# Altium schematic sheet Motor_driver.SchDoc
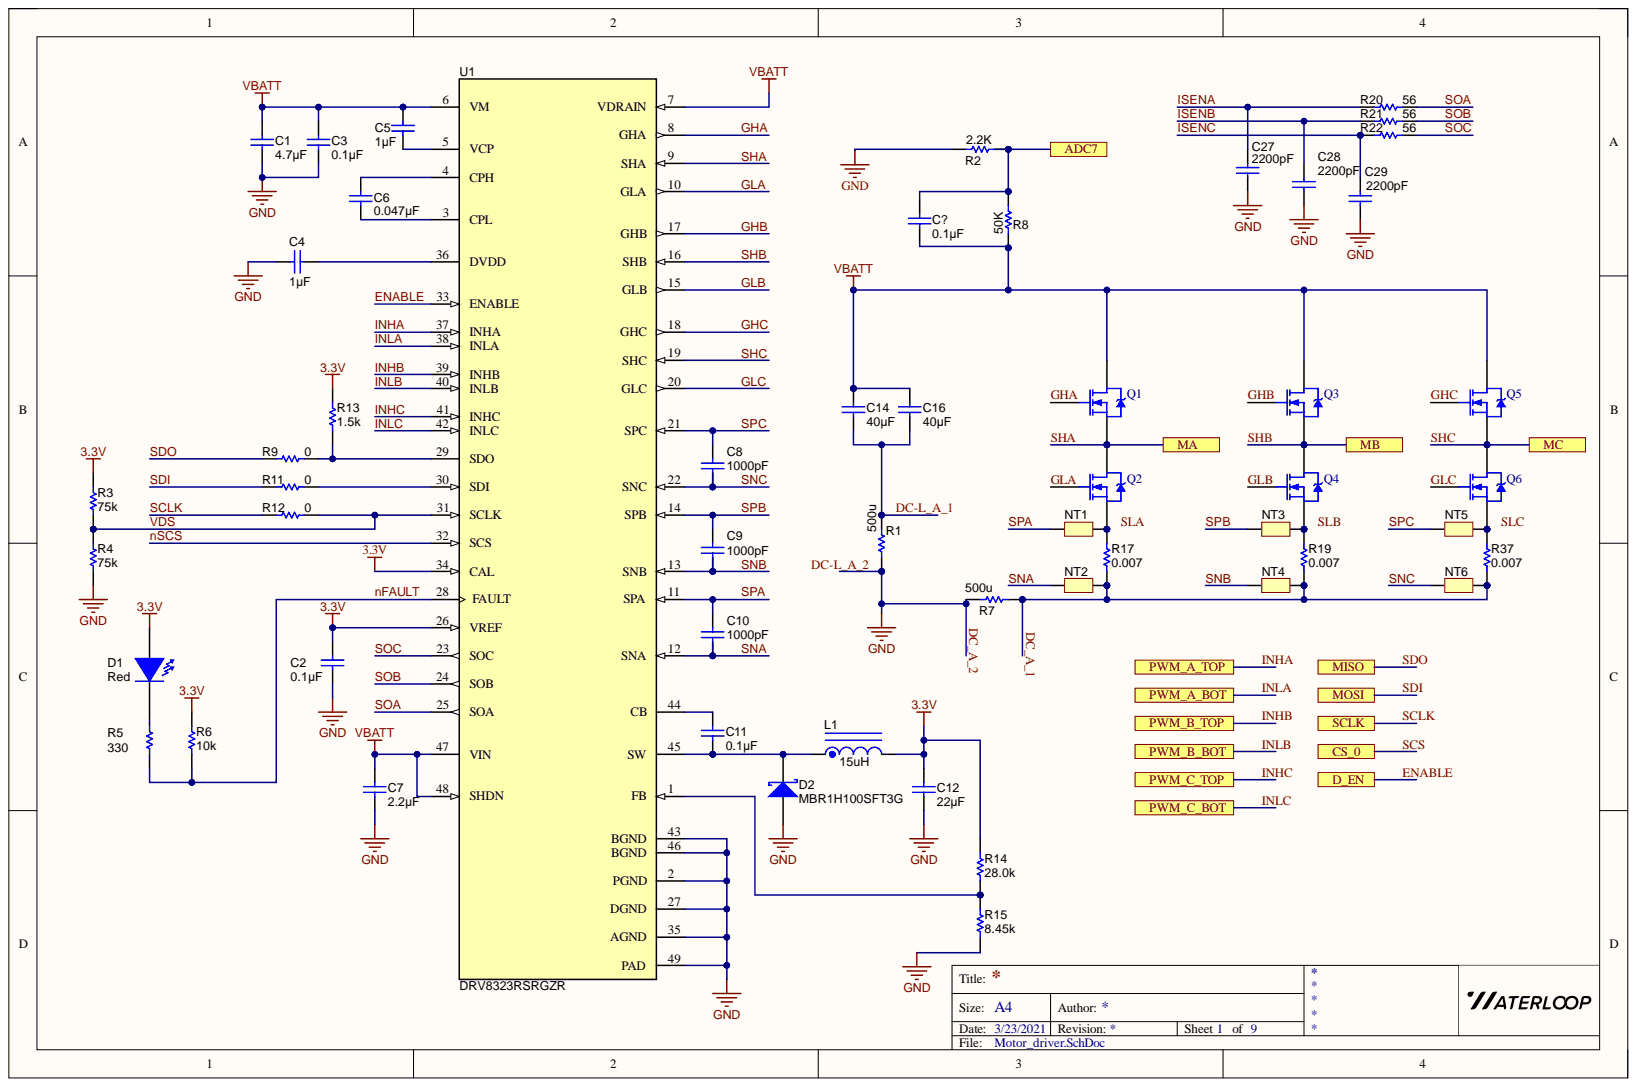
[diagram p0: Motor_driver.SchDoc]

## Parts
C1  ValueDisplayed: 4.7µF  [1210_270L]  (hidden comment: GRM32ER71K475KE14L)
C2  ValueDisplayed: 0.1µF  [0603L]  (hidden comment: GRM188R70J104KA01D)
C3  ValueDisplayed: 0.1µF  [0603L]  (hidden comment: GRM188R72A104KA35D)
C4  ValueDisplayed: 1µF  [0603L]  (hidden comment: GRM188R70J105KA01D)
C5  ValueDisplayed: 1µF  [0603L]  (hidden comment: 885012206052)
C6  ValueDisplayed: 0.047µF  [0603L]  (hidden comment: C1608X7S2A473K080AB)
C7  ValueDisplayed: 2.2µF  [1210L]  (hidden comment: HMK325B7225KN-T)
C8  value 1000pF  [0603L]  (hidden comment: GRM188R71C102KA01D)
C9  value 1000pF  [0603L]  (hidden comment: GRM188R71C102KA01D)
C10  value 1000pF  [0603L]  (hidden comment: GRM188R71C102KA01D)
C11  ValueDisplayed: 0.1µF  [0603L]  (hidden comment: 0603YC104JAT2A)
C12  ValueDisplayed: 22µF  [1206_180L]  (hidden comment: C3216X7S1A226M160AC)
C14  ValueDisplayed: 40µF  [0603L]  (hidden comment: 0603YC104JAT2A)
C16  ValueDisplayed: 40µF  [0603L]  (hidden comment: 0603YC104JAT2A)
C27  value 2200pF  [0603L]  (hidden comment: GRM188R71C222KA01D)
C28  value 2200pF  [0603L]  (hidden comment: GRM188R71C222KA01D)
C29  value 2200pF  [0603L]  (hidden comment: GRM188R71C222KA01D)
C?  ValueDisplayed: 0.1µF  [0603L]  (hidden comment: 0603YC104JAT2A)
D1  value Red  [LED_LTST-C170]  (hidden comment: LTST-C170KRKT)
D2  MBR1H100SFT3G  [SOD-123FL]
L1  value 15uH  [SD53]  (hidden comment: SD53-150-R)
NT1  Net-Tie  [Net-Tie_10mil]  (value not drawn)
NT2  Net-Tie  [Net-Tie_10mil]  (value not drawn)
NT3  Net-Tie  [Net-Tie_10mil]  (value not drawn)
NT4  Net-Tie  [Net-Tie_10mil]  (value not drawn)
NT5  Net-Tie  [Net-Tie_10mil]  (value not drawn)
NT6  Net-Tie  [Net-Tie_10mil]  (value not drawn)
Q1  NMOS-2  [TO-220AB]  (value not drawn)
Q2  NMOS-2  [TO-220AB]  (value not drawn)
Q3  NMOS-2  [TO-220AB]  (value not drawn)
Q4  NMOS-2  [TO-220AB]  (value not drawn)
Q5  NMOS-2  [TO-220AB]  (value not drawn)
Q6  NMOS-2  [TO-220AB]  (value not drawn)
R1  ValueDisplayed: 500u  [2512L]  (hidden comment: CRE2512-FZ-R007E-3)
R2  ValueDisplayed: 2.2K  [2512L]  (hidden comment: CRE2512-FZ-R007E-3)
R3  value 75k  [0603L]  (hidden comment: CRCW060375K0JNEA)
R4  value 75k  [0603L]  (hidden comment: CRCW060375K0JNEA)
R5  value 330  [0603L]  (hidden comment: CRCW0603330RJNEA)
R6  value 10k  [0603L]  (hidden comment: CRCW060310K0JNEA)
R7  ValueDisplayed: 500u  [2512L]  (hidden comment: CRE2512-FZ-R007E-3)
R8  ValueDisplayed: 50K  [2512L]  (hidden comment: CRE2512-FZ-R007E-3)
R9  value 0  [0603L]  (hidden comment: CRCW06030000Z0EA)
R11  value 0  [0603L]  (hidden comment: CRCW06030000Z0EA)
R12  value 0  [0603L]  (hidden comment: CRCW06030000Z0EA)
R13  value 1.5k  [0603L]  (hidden comment: CRCW06031K50JNEA)
R14  value 28.0k  [0603L]  (hidden comment: CRCW060328K0FKEA)
R15  value 8.45k  [0603L]  (hidden comment: CRCW06038K45FKEA)
R17  value 0.007  Res_ohm: 0.007  [2512L]  (hidden comment: CRE2512-FZ-R007E-3)
R19  value 0.007  Res_ohm: 0.007  [2512L]  (hidden comment: CRE2512-FZ-R007E-3)
R20  value 56  [0603L]  (hidden comment: CRCW060356R0JNEA)
R21  value 56  [0603L]  (hidden comment: CRCW060356R0JNEA)
R22  value 56  [0603L]  (hidden comment: CRCW060356R0JNEA)
R37  value 0.007  Res_ohm: 0.007  [2512L]  (hidden comment: CRE2512-FZ-R007E-3)
U1  DRV8323RSRGZR  [RGZ0048B]

## Ports
ADC7, CS_0, D_EN, MA, MB, MC, MISO, MOSI, PWM_A_BOT, PWM_A_TOP, PWM_B_BOT, PWM_B_TOP, PWM_C_BOT, PWM_C_TOP, SCLK

## Nets
3.3V: C2.1 C12.1 D1.1 L1.2 R3.2 R6.2 R13.2 R14.2 U1.26(VREF) U1.34(CAL)
VBATT: C1.1 C3.1 C5.1 C7.1 C14.1 C16.1 C?.2 Q1.2 Q3.2 Q5.2 R8.2 U1.6(VM) U1.7(VDRAIN) U1.47(VIN) U1.48(SHDN)
ADC7: C?.1 R2.1 R8.1 ; port ADC7
DC-L_A_1: C14.2 C16.2 R1.1
DC_A_2: C1.2 C2.2 C3.2 C4.1 C7.2 C12.2 C27.2 C28.1 C29.2 D2.2 R1.2 R2.2 R4.1 R7.2 R15.1 U1.2(PGND) U1.27(DGND) U1.35(AGND) U1.43(BGND) U1.46(BGND) U1.49(PAD)
ENABLE: U1.33(ENABLE) ; port D_EN
GHA: Q1.1 U1.8(GHA)
GHB: Q3.1 U1.17(GHB)
GHC: Q5.1 U1.18(GHC)
GLA: Q2.1 U1.10(GLA)
GLB: Q4.1 U1.15(GLB)
GLC: Q6.1 U1.20(GLC)
INHA: U1.37(INHA) ; port PWM_A_TOP
INHB: U1.39(INHB) ; port PWM_B_TOP
INHC: U1.41(INHC) ; port PWM_C_TOP
INLA: U1.38(INLA) ; port PWM_A_BOT
INLB: U1.40(INLB) ; port PWM_B_BOT
INLC: U1.42(INLC) ; port PWM_C_BOT
ISENA: C27.1 R20.1
ISENB: C28.2 R21.1
ISENC: C29.1 R22.1
nFAULT: R5.1 R6.1 U1.28(FAULT)
nSCS: U1.32(SCS)
SCLK: R12.2 ; port SCLK
SDI: R11.2 ; port MOSI
SDO: R9.2 ; port MISO
SHA: Q1.3 Q2.2 U1.9(SHA) ; port MA
SHB: Q3.3 Q4.2 U1.16(SHB) ; port MB
SHC: Q5.3 Q6.2 U1.19(SHC) ; port MC
SNA: C10.1 NT2.1 U1.12(SNA)
SNB: C9.1 NT4.1 U1.13(SNB)
SNC: C8.1 NT6.1 U1.22(SNC)
SOA: R20.2 U1.25(SOA)
SOB: R21.2 U1.24(SOB)
SOC: R22.2 U1.23(SOC)
SPA: C10.2 NT1.1 U1.11(SPA)
SPB: C9.2 NT3.1 U1.14(SPB)
SPC: C8.2 NT5.1 U1.21(SPC)
VDS: R3.1 R4.2 R12.1 U1.31(SCLK)
NetC4_2: C4.2 U1.36(DVDD)
NetC5_2: C5.2 U1.5(VCP)
NetC6_1: C6.1 U1.4(CPH)
NetC6_2: C6.2 U1.3(CPL)
NetC11_1: C11.1 U1.44(CB)
NetC11_2: C11.2 D2.1 L1.1 U1.45(SW)
NetD1_2: D1.2 R5.2
NetNT1_2: NT1.2 Q2.3 R17.2
NetNT2_2: NT2.2 NT4.2 NT6.2 R7.1 R17.1 R19.1 R37.1
NetNT3_2: NT3.2 Q4.3 R19.2
NetNT5_2: NT5.2 Q6.3 R37.2
NetR9_1: R9.1 R13.1 U1.29(SDO)
NetR11_1: R11.1 U1.30(SDI)
NetR14_1: R14.1 R15.2 U1.1(FB)
DC-L_A_2:
DC_A_1:
SCS: ; port CS_0
SLA:
SLB:
SLC:

## Library data of the parts
C1 = GRM32ER71K475KE14L: CAP, CERM, 4.7 µF, 80 V, +/- 10%, X7R, 1210; Manufacturer: MuRata; AEC Category: Q200; AEC Qualified: N; Cap_Case: 1210; Cap_F: 0.0000047; CompType: Capacitor; SizeArea_mm2: 8; SizeAreaWB_mm2: 14.7; SizeX_mm: 3.2; SizeY_mm: 2.5; SizeZ_mm: 2.7; TaMax_C: 125; TaMin_C: -55; Tolerance_%: 10; U/M: EA; Value: 4.7uF; Value1: Unknown; Value2: 80V; VDC_V: 80; AEC Grade: N/A; Component Kind: Standard; ComponentLink1Description: Datasheet link; ComponentLink1URL: http://psearch.en.murata.com/capacitor/product/GRM32ER71K475KE14%23.pdf; LatestRevisionDate: 2016-12-05; Library Name: Capacitor_Ceramic.IntLib; MountType: SMT; PackageReference: 1210; PartNumber: GRM32ER71K475KE14L; Polarized: N; Published: 2008-06-19; RoHS: Y; Signal Integrity: Cap; Simulation: Cap; Supplier 1: Digi-Key; Supplier 2: Mouser; Supplier Part Number 1: 490-9972-1-ND; Supplier Part Number 2: 81-GRM32ER71K475KE4L; SymbolVersion: 2008-07-09; Technology: Ceramic; TempCo: X7R; Publisher: Texas Instruments
C2 = GRM188R70J104KA01D: CAP, CERM, 0.1 µF, 6.3 V, +/- 10%, X7R, 0603; Manufacturer: MuRata; AEC Category: Q200; Cap_Case: 0603; Cap_F: 0.0000001; CompType: Capacitor; Polarized: N; TaMax_C: 125; TaMin_C: -55; Tolerance_%: 10; U/M: EA; Value: 0.1uF; Value1: Unknown; Value2: 6.3V; VDC_V: 6.3; AEC Qualified: ?; Component Kind: Standard; ComponentLink1Description: Datasheet link; ComponentLink1URL: http://search.murata.co.jp/Ceramy/PnsearchViewAction.do?HnOFG=ON&HnNFG=ON&SEL_CNT=50&sLang=en&sKey=GRM188R70J104KA01D&x=9&y=9&search=startwith; LatestRevisionDate: 2016-03-19; Library Name: Capacitor_Ceramic.IntLib; MountType: SMT; PackageReference: 0603; PartNumber: GRM188R70J104KA01D; Published: 2008-06-19; RoHS: Y; Signal Integrity: Cap; Simulation: Cap; Supplier 1: Digi-Key; Supplier 2: Mouser; Supplier Part Number 1: GRM188R70J104KA01D-ND; Supplier Part Number 2: 81-GRM188R70J104KA1D; SymbolVersion: 2008-07-09; Technology: Ceramic; TempCo: X7R; Publisher: Texas Instruments
C3 = GRM188R72A104KA35D: CAP, CERM, 0.1 µF, 100 V, +/- 10%, X7R, 0603; Manufacturer: MuRata; AEC Category: Q200; Cap_Case: 0603; Cap_F: 0.0000001; CompType: Capacitor; Polarized: N; TaMax_C: 125; TaMin_C: -55; Tolerance_%: 10; U/M: EA; Value: 0.1uF; Value1: Unknown; Value2: 100V; VDC_V: 100; AEC Qualified: ?; Component Kind: Standard; ComponentLink1Description: Datasheet link; ComponentLink1URL: http://search.murata.co.jp/Ceramy/PnsearchViewAction.do?HnOFG=ON&HnNFG=ON&SEL_CNT=50&sLang=en&sKey=GRM188R72A104KA35D&x=9&y=9&search=startwith; LatestRevisionDate: 2016-03-19; Library Name: Capacitor_Ceramic.IntLib; MountType: SMT; PackageReference: 0603; PartNumber: GRM188R72A104KA35D; Published: 2008-06-19; RoHS: Y; Signal Integrity: Cap; Simulation: Cap; Supplier 1: Digi-Key; Supplier 2: Mouser; Supplier 3: Farnell; Supplier Part Number 1: 490-3285-1-ND; Supplier Part Number 2: 81-GRM188R72A104KA35; Supplier Part Number 3: 1828921; SymbolVersion: 2008-07-09; Technology: Ceramic; TempCo: X7R; Publisher: Texas Instruments
C4 = GRM188R70J105KA01D: CAP, CERM, 1 µF, 6.3 V, +/- 10%, X7R, 0603; Manufacturer: MuRata; AEC Category: Q200; Cap_Case: 0603; Cap_F: 0.000001; CompType: Capacitor; Polarized: N; TaMax_C: 125; TaMin_C: -55; Tolerance_%: 10; U/M: EA; Value: 1uF; Value1: Unknown; Value2: 6.3V; VDC_V: 6.3; AEC Qualified: ?; Component Kind: Standard; ComponentLink1Description: Datasheet link; ComponentLink1URL: http://search.murata.co.jp/Ceramy/PnsearchViewAction.do?HnOFG=ON&HnNFG=ON&SEL_CNT=50&sLang=en&sKey=GRM188R70J105KA01D&x=9&y=9&search=startwith; LatestRevisionDate: 2016-03-19; Library Name: Capacitor_Ceramic.IntLib; MountType: SMT; PackageReference: 0603; PartNumber: GRM188R70J105KA01D; Published: 2008-06-19; RoHS: Y; Signal Integrity: Cap; Simulation: Cap; Supplier 1: Digi-Key; Supplier 2: Mouser; Supplier 3: Farnell; Supplier Part Number 1: 490-3898-1-ND; Supplier Part Number 2: 81-GRM188R70J105KA01; Supplier Part Number 3: 1845765; SymbolVersion: 2008-07-09; Technology: Ceramic; TempCo: X7R; Publisher: Texas Instruments
C5 = 885012206052: CAP, CERM, 1 µF, 16 V, +/- 10%, X7R, 0603; Manufacturer: Wurth Elektronik; AEC Category: Q200; AEC Qualified: N; Cap_Case: 0603; Cap_F: 0.000001; CompType: Capacitor; TaMax_C: 125; TaMin_C: -55; Tolerance_%: 10; U/M: EA; Value: 1uF; Value1: Unknown; Value2: 16V; VDC_V: 16; AEC Grade: N/A; Component Kind: Standard; ComponentLink1Description: Datasheet link; ComponentLink1URL: http://katalog.we-online.de/pbs/datasheet/885012206052.pdf; LatestRevisionDate: 2016-03-19; Library Name: Capacitor_Ceramic.IntLib; MountType: SMT; PackageReference: 0603; PartNumber: 885012206052; Polarized: N; Published: 2008-06-19; RoHS: Y; Signal Integrity: Cap; Simulation: Cap; Supplier 1: Mouser; Supplier Part Number 1: 710-885012206052; SymbolVersion: 2008-07-09; Technology: Ceramic; TempCo: X7R; Publisher: Texas Instruments
C6 = C1608X7S2A473K080AB: CAP, CERM, 0.047 µF, 100 V, +/- 10%, X7S, 0603; Manufacturer: TDK; AEC Category: Q200; AEC Qualified: N; Cap_Case: 0603; Cap_F: 0.000000047; CompType: Capacitor; ESR_ohm: 0.089796; IRMS_A: 0.567484; SizeArea_mm2: 1.28; SizeAreaWB_mm2: 4.68; SizeX_mm: 1.6; SizeY_mm: 0.8; SizeZ_mm: 1; TaMax_C: 125; TaMin_C: -55; Tolerance_%: 10; U/M: EA; Value: 0.047uF; Value1: 0.089796 ohm; Value2: 100V; VDC_V: 100; AEC Grade: N/A; Component Kind: Standard; ComponentLink1Description: Datasheet link; ComponentLink1URL: https://product.tdk.com/en/search/capacitor/ceramic/mlcc/info?part_no=C1608X7S2A473K080AB; LatestRevisionDate: 2016-12-05; Library Name: Capacitor_Ceramic.IntLib; MountType: SMT; PackageReference: 0603; PartNumber: C1608X7S2A473K080AB; Polarized: N; Published: 2008-06-19; RoHS: Y; Signal Integrity: Cap; Simulation: Cap; Supplier 1: Digi-Key; Supplier 2: Mouser; Supplier Part Number 1: 445-6032-1-ND; Supplier Part Number 2: 810-C1608X7S2A473K080AB; SymbolVersion: 2008-07-09; Technology: Ceramic; TempCo: X7S; Publisher: Texas Instruments
C7 = HMK325B7225KN-T: CAP, CERM, 2.2 µF, 100 V, +/- 10%, X7R, 1210; Manufacturer: Taiyo Yuden; AEC Category: Q200; Cap_Case: 1210; Cap_F: 0.0000022; CompType: Capacitor; Polarized: N; SizeArea_mm2: 8; SizeAreaWB_mm2: 14.7; SizeX_mm: 3.2; SizeY_mm: 2.5; SizeZ_mm: 1.35; TaMax_C: 125; TaMin_C: -55; Tolerance_%: 10; U/M: EA; Value: 2.2uF; Value1: Unknown; Value2: 100V; VDC_V: 100; AEC Qualified: ?; Component Kind: Standard; ComponentLink1Description: Datasheet link; ComponentLink1URL: http://www.yuden.co.jp/ut/product/pdf/dhmk_e.pdf; LatestRevisionDate: 2016-12-05; Library Name: Capacitor_Ceramic.IntLib; MountType: SMT; PackageReference: 1210; PartNumber: HMK325B7225KN-T; Published: 2008-06-19; RoHS: Y; Signal Integrity: Cap; Simulation: Cap; Supplier 1: Digi-Key; Supplier 2: Farnell; Supplier 3: Newark; Supplier Part Number 1: 587-1778-1-ND; Supplier Part Number 2: 1735547; Supplier Part Number 3: 08P5140; SymbolVersion: 2008-07-09; Technology: Ceramic; TempCo: X7R; Publisher: Texas Instruments
C8-C10 = GRM188R71C102KA01D: CAP, CERM, 1000pF, 16V, +/-10%, X7R, 0603; Manufacturer: MuRata; Cap_Case: 0603; Cap_F: 0.000000001; CompType: Capacitor; Polarized: N; TaMax_C: 125; TaMin_C: -55; Tolerance_%: 10; U/M: EA; Value1: Unknown; VDC_V: 16; Component Kind: Standard; ComponentLink1Description: Datasheet link; ComponentLink1URL: http://search.murata.co.jp/Ceramy/PnsearchViewAction.do?HnOFG=ON&HnNFG=ON&SEL_CNT=50&sLang=en&sKey=GRM188R71C102KA01D&x=9&y=9&search=startwith; LatestRevisionDate: 2014-07-08; Library Name: Capacitor_Ceramic.IntLib; MountType: SMT; PackageReference: 0603; PartNumber: GRM188R71C102KA01D; Published: 2008-06-19; RoHS: Y; Signal Integrity: Cap; Simulation: Cap; Supplier 1: Digi-Key; Supplier 2: Mouser; Supplier Part Number 1: GRM188R71C102KA01D-ND; Supplier Part Number 2: 81-GRM188R71C102KA1D; SymbolVersion: 2008-07-09; Technology: Ceramic; TempCo: X7R; Value2: 16V; ValueDisplayed: 1000pF; Publisher: Texas Instruments
C11 C14 C16 C? = 0603YC104JAT2A: CAP, CERM, 0.1 µF, 16 V, +/- 5%, X7R, 0603; Manufacturer: AVX; AEC Category: Q200; AEC Qualified: N; Cap_Case: 0603; Cap_F: 0.0000001; CompType: Capacitor; ESR_ohm: 0.039; TaMax_C: 125; TaMin_C: -55; Tolerance_%: 5; U/M: EA; Value: 0.1uF; Value1: 0.039 ohm; Value2: 16V; VDC_V: 16; AEC Grade: N/A; Component Kind: Standard; ComponentLink1Description: Datasheet link; ComponentLink1URL: http://datasheets.avx.com/X7RDielectric.pdf; LatestRevisionDate: 2016-03-19; Library Name: Capacitor_Ceramic.IntLib; MountType: SMT; PackageReference: 0603; PartNumber: 0603YC104JAT2A; Polarized: N; Published: 2008-06-19; RoHS: Y; Signal Integrity: Cap; Simulation: Cap; Supplier 1: Digi-Key; Supplier 2: Mouser; Supplier 3: Farnell; Supplier Part Number 1: 478-3726-1-ND; Supplier Part Number 2: 581-0603YC104J; Supplier Part Number 3: 1740612; SymbolVersion: 2008-07-09; Technology: Ceramic; TempCo: X7R; Publisher: Texas Instruments
C12 = C3216X7S1A226M160AC: CAP, CERM, 22 µF, 10 V, +/- 20%, X7S, 1206; Manufacturer: TDK; AEC Category: Q200; AEC Qualified: N; Cap_Case: 1206_180; Cap_F: 0.000022; CompType: Capacitor; ESR_ohm: 0.002398; IRMS_A: 4.68507; SizeArea_mm2: 5.12; SizeAreaWB_mm2: 10.92; SizeX_mm: 3.2; SizeY_mm: 1.6; SizeZ_mm: 1.8; TaMax_C: 125; TaMin_C: -55; Tolerance_%: 20; U/M: EA; Value: 22uF; Value1: 0.002398 ohm; Value2: 10V; VDC_V: 10; AEC Grade: N/A; Component Kind: Standard; ComponentLink1Description: Datasheet link; ComponentLink1URL: https://product.tdk.com/en/search/capacitor/ceramic/mlcc/info?part_no=C3216X7S1A226M160AC; LatestRevisionDate: 2016-12-05; Library Name: Capacitor_Ceramic.IntLib; MountType: SMT; PackageReference: 1206; PartNumber: C3216X7S1A226M160AC; Polarized: N; Published: 2008-06-19; RoHS: Y; Signal Integrity: Cap; Simulation: Cap; Supplier 1: Digi-Key; Supplier 2: Mouser; Supplier Part Number 1: 445-8035-1-ND; Supplier Part Number 2: 810-C3216X7S1A226M160AC; SymbolVersion: 2008-07-09; Technology: Ceramic; TempCo: X7S; Publisher: Texas Instruments
C27-C29 = GRM188R71C222KA01D: CAP, CERM, 2200pF, 16V, +/-10%, X7R, 0603; Manufacturer: MuRata; Cap_Case: 0603; Cap_F: 0.0000000022; CompType: Capacitor; Polarized: N; TaMax_C: 125; TaMin_C: -55; Tolerance_%: 10; U/M: EA; Value1: Unknown; VDC_V: 16; Component Kind: Standard; ComponentLink1Description: Datasheet link; ComponentLink1URL: http://search.murata.co.jp/Ceramy/PnsearchViewAction.do?HnOFG=ON&HnNFG=ON&SEL_CNT=50&sLang=en&sKey=GRM188R71C222KA01D&x=9&y=9&search=startwith; LatestRevisionDate: 2014-02-11; Library Name: Capacitor_Ceramic.IntLib; MountType: SMT; PackageReference: 0603; PartNumber: GRM188R71C222KA01D; Published: 2008-06-19; RoHS: Y; Signal Integrity: Cap; Simulation: Cap; Supplier 1: Digi-Key; Supplier 2: Mouser; Supplier Part Number 1: GRM188R71C222KA01D-ND; Supplier Part Number 2: 81-GRM188R71C222KA1D; SymbolVersion: 2008-07-09; Technology: Ceramic; TempCo: X7R; Value2: 16V; ValueDisplayed: 2200pF; Publisher: Texas Instruments
D1 = LTST-C170KRKT: LED, Red, SMD; Manufacturer: Lite-On; Io_A: 20; Type: AlInGaP; U/M: EA; Value1: W; Value2: 2V; Vf_V: 2; Color: red; Component Kind: Standard; ComponentLink1Description: Datasheet link; ComponentLink1URL: http://optoelectronics.liteon.com/en-us/api/DwonloadFileHandler.ashx?txtSpecNo=DS-22-99-0150&txtPartNo=LTST-C170KRKT; CompType: LED; LatestRevisionDate: 2013-09-07; Library Name: LED.IntLib; MountType: SMT; PackageReference: LED_0805; PartNumber: LTST-C170KRKT; Published: 2008-07-11; RoHS: Y; Signal Integrity: Diode; Supplier 1: Digi-Key; Supplier 2: Mouser; Supplier Part Number 1: 160-1415-1-ND; Supplier Part Number 2: 859-LTST-C170KRKT; SymbolVersion: 2008-12-08; Publisher: Texas Instruments
D2 = MBR1H100SFT3G: Diode, Schottky, 100 V, 1 A, SOD-123FL; Manufacturer: ON Semiconductor; AEC Category: Q101; Io_A: 1; RJC_CperW: 85; SizeArea_mm2: 5.94; SizeAreaWB_mm2: 12.19; SizeX_mm: 3.6; SizeY_mm: 1.65; SizeZ_mm: 0.98; TJmax_C: 175; U/M: EA; Value: 100V; Value1: 1A; Value2: 0.76V; Vf_V: 0.76; Vr_V: 100; AEC Qualified: ?; Component Kind: Standard; ComponentLink1Description: Datasheet link; ComponentLink1URL: http://www.onsemi.com/pub_link/Collateral/MBR1H100SF-D.PDF; CompType: Diode; LatestRevisionDate: 2016-12-06; Library Name: Diode.IntLib; MountType: SMT; PackageReference: SOD-123FL; PartNumber: MBR1H100SFT3G; Published: 2008-06-26; RoHS: Y; Signal Integrity: Diode; Supplier 1: Digi-Key; Supplier 2: Mouser; Supplier Part Number 1: MBR1H100SFT3GOSCT-ND; Supplier Part Number 2: 863-MBR1H100SFT3G; SymbolVersion: 2008-12-08; Type: Schottky; Publisher: Texas Instruments
L1 = SD53-150-R: Inductor, Shielded Drum Core, Ferrite, 15 µH, 1.1 A, 0.122 ohm, SMD; Manufacturer: Cooper Bussman; DCR_ohm: 0.122; Imax_A: 1.1; Ind_H: 15.0E-6; Irms: 1.1A @ 40C rise; Isat: 1.1A @ 30% inductance drop; SymbolCreator: a0412235; Value2: 1.1A; ValueDisplayed: 15µH; Component Kind: Standard; ComponentLink1Description: Datasheet link; ComponentLink1URL: http://www.cooperindustries.com/content/dam/public/bussmann/Electronics/Resources/Data%20Sheets/Bus_Elx_DS_PM%204149_SD53_Series.pdf; CompType: Inductor; LatestRevisionDate: 2015-02-09; Library Name: Inductor_Other.IntLib; Material: Ferrite; MountType: SMT; PackageReference: 5.72x3x5.2mm; PartNumber: SD53-150-R; Published: 2008-06-26; RoHS: Y; Signal Integrity: Inductor; Simulation: Inductor; Supplier 1: Digi-Key; Supplier 2: Mouser; Supplier 3: Newark; Supplier Part Number 1: 513-1458-1-ND; Supplier Part Number 2: 704-SD53-150-R; Supplier Part Number 3: 99M5528; SymbolVersion: 2013-02-25; Technology: Shielded Drum Core; Value1: 0.122 ohm; Publisher: Texas Instruments
NT1-NT6 = Net-Tie: Single point connection between nets.; SymbolCreator: codlsc; Component Kind: Net Tie; LatestRevisionDate: 2014-12-30; LatestRevisionNote: Added Alternate PartNumber and Alternate Manufacturer parameters to appropriate components.; Library Name: MiscParts.IntLib; MountType: SMT; PackageReference: N/A; SymbolVersion: 2009-07-01; Publisher: Texas Instruments
Q1-Q6 = NMOS-2: N-Channel Power MOSFET; Note: Pin 2 is electrically connected to pin 4.; Component Kind: Standard; ComponentLink1Description: Manufacturer Link; ComponentLink1URL: http://www.irf.com/; DatasheetVersion: PD-9.814; LatestRevisionDate: 17-Jul-2002; LatestRevisionNote: Re-released for DXP Platform.; Library Name: Miscellaneous Devices.IntLib; PackageDescription: TO, Thru-Hole, Vertical, Heatsink Mounted; 3 In-Line Leads; Pitch 2.54 mm; PackageReference: TO-220AB; PackageVersion: Feb-1997; Published: 8-Jun-2000; Simulation: IRF1010; Publisher: Altium Limited
R1 R2 R7 R8 = PMR100HZPFU7L00: RES SMD 0.007 OHM 1% 3W 2512; Manufacturer: Bourns Inc.; CompType: Resistor; EIA_Code: E96; Power_W: 3; Res_ohm: 0.007; TempCo: 100 ppm/C; Tolerance_%: 1; U/M: EA; Value: 0.007; Value_Code: 7L000; Component Kind: Standard; ComponentLink1Description: Datasheet link; ComponentLink1URL: http://media.digikey.com/pdf/Data%20Sheets/Rohm%20PDFs/PMR100-SERIES.pdf; LatestRevisionDate: 2014-12-15; Library Name: Resistor_2512.IntLib; MountType: SMT; PackageReference: 2512; PartNumber: CRE2512-FZ-R007E-3; Published: 2008-06-26; RoHS: Y; Signal Integrity: Resistor; Simulation: Resistor; Supplier 1: Digi-Key; Supplier Part Number 1: CRE2512-FZ-R007E-3CT-ND; SymbolVersion: 2009-05-29; Technology: Thick Film; Value1: 1%; Value2: 3W; Publisher: Texas Instruments
R3 R4 = CRCW060375K0JNEA: RES, 75 k, 5%, 0.1 W, 0603; Manufacturer: Vishay-Dale; AEC Category: Q200; CompType: Resistor; EIA_Code: E24; Power_W: 0.1; Res_ohm: 75000; TempCo: 200 ppm/C; Tolerance_%: 5; U/M: EA; Value2: 0.1W; Value_Code: 75k0; VDC_V: 75; AEC Qualified: ?; Component Kind: Standard; ComponentLink1Description: Datasheet link; ComponentLink1URL: http://www.vishay.com/docs/20035/dcrcwe3.pdf; LatestRevisionDate: 2016-03-05; Library Name: Resistor_0603.IntLib; MountType: SMT; PackageReference: 0603; PartNumber: CRCW060375K0JNEA; Published: 2008-06-26; RoHS: Y; Signal Integrity: Resistor; Simulation: Resistor; Supplier 1: Digi-Key; Supplier 2: Mouser; Supplier 3: Farnell; Supplier Part Number 1: 541-75KGCT-ND; Supplier Part Number 2: 71-CRCW0603J-75K-E3; Supplier Part Number 3: 1570763; SymbolVersion: 2009-05-29; Technology: Thick Film; Value1: 5%; ValueDisplayed: 75k; Publisher: Texas Instruments
R5 = CRCW0603330RJNEA: RES, 330 ohm, 5%, 0.1W, 0603; Manufacturer: Vishay-Dale; CompType: Resistor; EIA_Code: E24; Power_W: 0.1; TempCo: 200 ppm/C; Tolerance_%: 5; U/M: EA; Value_Code: 330R; VDC_V: 75; Component Kind: Standard; ComponentLink1Description: Datasheet link; ComponentLink1URL: http://www.vishay.com/docs/20035/dcrcwe3.pdf; LatestRevisionDate: 2013-10-22; Library Name: Resistor_0603.IntLib; MountType: SMT; PackageReference: 0603; PartNumber: CRCW0603330RJNEA; Published: 2008-06-26; Res_ohm: 330; RoHS: Y; Signal Integrity: Resistor; Simulation: Resistor; Supplier 1: Digi-Key; Supplier 2: Mouser; Supplier 3: Farnell; Supplier Part Number 1: 541-330GCT-ND; Supplier Part Number 2: 71-CRCW0603J-330-E3; Supplier Part Number 3: 1692522; SymbolVersion: 2009-05-29; Technology: Thick Film; Value1: 5%; Value2: 0.1W; Publisher: Texas Instruments
R6 = CRCW060310K0JNEA: RES, 10 k, 5%, 0.1 W, 0603; Manufacturer: Vishay-Dale; AEC Category: Q200; CompType: Resistor; EIA_Code: E24; Power_W: 0.1; Res_ohm: 10000; TempCo: 200 ppm/C; Tolerance_%: 5; U/M: EA; Value2: 0.1W; Value_Code: 10k0; VDC_V: 75; AEC Qualified: ?; Component Kind: Standard; ComponentLink1Description: Datasheet link; ComponentLink1URL: http://www.vishay.com/docs/20035/dcrcwe3.pdf; LatestRevisionDate: 2016-03-05; Library Name: Resistor_0603.IntLib; MountType: SMT; PackageReference: 0603; PartNumber: CRCW060310K0JNEA; Published: 2008-06-26; RoHS: Y; Signal Integrity: Resistor; Simulation: Resistor; Supplier 1: Digi-Key; Supplier 2: Mouser; Supplier 3: Farnell; Supplier Part Number 1: 541-10KGCT-ND; Supplier Part Number 2: 71-CRCW0603J-10K-E3; Supplier Part Number 3: 1469749; SymbolVersion: 2009-05-29; Technology: Thick Film; Value1: 5%; ValueDisplayed: 10k; Publisher: Texas Instruments
R9 R11 R12 = CRCW06030000Z0EA: RES, 0, 5%, 0.1 W, 0603; Manufacturer: Vishay-Dale; AEC Category: Q200; CompType: Resistor; EIA_Code: E24; Power_W: 0.1; TempCo: 200 ppm/C; Tolerance_%: 5; U/M: EA; Value2: 0.1W; Value_Code: 0000; VDC_V: 75; AEC Qualified: ?; Component Kind: Standard; ComponentLink1Description: Datasheet link; ComponentLink1URL: http://www.vishay.com/docs/20035/dcrcwe3.pdf; LatestRevisionDate: 2015-12-05; Library Name: Resistor_0603.IntLib; MountType: SMT; PackageReference: 0603; PartNumber: CRCW06030000Z0EA; Published: 2008-06-26; Res_ohm: 0; RoHS: Y; Signal Integrity: Resistor; Simulation: Resistor; Supplier 1: Digi-Key; Supplier 2: Mouser; Supplier 3: Farnell; Supplier Part Number 1: 541-0.0GCT-ND; Supplier Part Number 2: 71-CRCW0603-0-E3; Supplier Part Number 3: 1469739; SymbolVersion: 2009-05-29; Technology: Thick Film; Value1: 5%; ValueDisplayed: 0; Publisher: Texas Instruments
R13 = CRCW06031K50JNEA: RES, 1.5 k, 5%, 0.1 W, 0603; Manufacturer: Vishay-Dale; AEC Category: Q200; CompType: Resistor; EIA_Code: E24; Power_W: 0.1; Res_ohm: 1500; TempCo: 200 ppm/C; Tolerance_%: 5; U/M: EA; Value2: 0.1W; Value_Code: 1k50; VDC_V: 75; AEC Qualified: ?; Component Kind: Standard; ComponentLink1Description: Datasheet link; ComponentLink1URL: http://www.vishay.com/docs/20035/dcrcwe3.pdf; LatestRevisionDate: 2016-03-05; Library Name: Resistor_0603.IntLib; MountType: SMT; PackageReference: 0603; PartNumber: CRCW06031K50JNEA; Published: 2008-06-26; RoHS: Y; Signal Integrity: Resistor; Simulation: Resistor; Supplier 1: Digi-Key; Supplier 2: Mouser; Supplier 3: Farnell; Supplier Part Number 1: 541-1.5KGCT-ND; Supplier Part Number 2: 71-CRCW0603J-1.5K-E3; Supplier Part Number 3: 1531366; SymbolVersion: 2009-05-29; Technology: Thick Film; Value1: 5%; ValueDisplayed: 1.5k; Publisher: Texas Instruments
R14 = CRCW060328K0FKEA: RES, 28.0 k, 1%, 0.1 W, 0603; Manufacturer: Vishay-Dale; AEC Category: Q200; CompType: Resistor; EIA_Code: E96; Power_W: 0.1; Res_ohm: 28000; TempCo: 100 ppm/C; Tolerance_%: 1; U/M: EA; Value2: 0.1W; Value_Code: 28k0; VDC_V: 75; AEC Qualified: ?; Component Kind: Standard; ComponentLink1Description: Datasheet link; ComponentLink1URL: http://www.vishay.com/docs/20035/dcrcwe3.pdf; LatestRevisionDate: 2016-03-05; Library Name: Resistor_0603.IntLib; MountType: SMT; PackageReference: 0603; PartNumber: CRCW060328K0FKEA; Published: 2008-06-26; RoHS: Y; Signal Integrity: Resistor; Simulation: Resistor; Supplier 1: Digi-Key; Supplier 2: Mouser; Supplier 3: Farnell; Supplier Part Number 1: 541-28.0KHCT-ND; Supplier Part Number 2: 71-CRCW0603-28K-E3; Supplier Part Number 3: 1151883; SymbolVersion: 2009-05-29; Technology: Thick Film; Value1: 1%; ValueDisplayed: 28.0k; Publisher: Texas Instruments
R15 = CRCW06038K45FKEA: RES, 8.45 k, 1%, 0.1 W, 0603; Manufacturer: Vishay-Dale; AEC Category: Q200; CompType: Resistor; EIA_Code: E96; Power_W: 0.1; Res_ohm: 8450; TempCo: 100 ppm/C; Tolerance_%: 1; U/M: EA; Value2: 0.1W; Value_Code: 8k45; VDC_V: 75; AEC Qualified: ?; Component Kind: Standard; ComponentLink1Description: Datasheet link; ComponentLink1URL: http://www.vishay.com/docs/20035/dcrcwe3.pdf; LatestRevisionDate: 2016-03-05; Library Name: Resistor_0603.IntLib; MountType: SMT; PackageReference: 0603; PartNumber: CRCW06038K45FKEA; Published: 2008-06-26; RoHS: Y; Signal Integrity: Resistor; Simulation: Resistor; Supplier 1: Digi-Key; Supplier 2: Mouser; Supplier 3: Farnell; Supplier Part Number 1: 541-8.45KHCT-ND; Supplier Part Number 2: 71-CRCW0603-8.45K-E3; Supplier Part Number 3: 1152135; SymbolVersion: 2009-05-29; Technology: Thick Film; Value1: 1%; ValueDisplayed: 8.45k; Publisher: Texas Instruments
R17 R19 R37 = PMR100HZPFU7L00: RES SMD 0.007 OHM 1% 3W 2512; Manufacturer: Bourns Inc.; CompType: Resistor; EIA_Code: E96; Power_W: 3; TempCo: 100 ppm/C; Tolerance_%: 1; U/M: EA; Value_Code: 7L000; Component Kind: Standard; ComponentLink1Description: Datasheet link; ComponentLink1URL: http://media.digikey.com/pdf/Data%20Sheets/Rohm%20PDFs/PMR100-SERIES.pdf; LatestRevisionDate: 2014-12-15; Library Name: Resistor_2512.IntLib; MountType: SMT; PackageReference: 2512; PartNumber: CRE2512-FZ-R007E-3; Published: 2008-06-26; RoHS: Y; Signal Integrity: Resistor; Simulation: Resistor; Supplier 1: Digi-Key; Supplier Part Number 1: CRE2512-FZ-R007E-3CT-ND; SymbolVersion: 2009-05-29; Technology: Thick Film; Value1: 1%; Value2: 3W; ValueDisplayed: 0.007; Publisher: Texas Instruments
R20-R22 = CRCW060356R0JNEA: RES, 56 ohm, 5%, 0.1W, 0603; Manufacturer: Vishay-Dale; CompType: Resistor; EIA_Code: E24; Power_W: 0.1; TempCo: 200 ppm/C; Tolerance_%: 5; U/M: EA; Value_Code: 56R0; VDC_V: 75; Component Kind: Standard; ComponentLink1Description: Datasheet link; ComponentLink1URL: http://www.vishay.com/docs/20035/dcrcwe3.pdf; LatestRevisionDate: 2014-01-08; Library Name: Resistor_0603.IntLib; MountType: SMT; PackageReference: 0603; PartNumber: CRCW060356R0JNEA; Published: 2008-06-26; Res_ohm: 56; RoHS: Y; Signal Integrity: Resistor; Simulation: Resistor; Supplier 1: Digi-Key; Supplier 2: Mouser; Supplier 3: Farnell; Supplier Part Number 1: 541-56GCT-ND; Supplier Part Number 2: 71-CRCW0603J-56-E3; Supplier Part Number 3: 1514781; SymbolVersion: 2009-05-29; Technology: Thick Film; Value1: 5%; Value2: 0.1W; Publisher: Texas Instruments
U1 = DRV8323RSRGZR: 60-V Three-Phase Smart Gate Driver, RGZ0048B; Alternate Manufacturer: Texas Instruments; Alternate PartNumber: DRV8323RSRGZT; CompType: IC; SymbolCreator: Altium; U/M: EA; Component Kind: Standard; ComponentLink1Description: Datasheet Link; ComponentLink1URL: https://sps06.itg.ti.com/sites/KMS/crossdivteams/altium/docs/ComponentDatasheets/DRV832x_031016.pdf; LatestRevisionDate: 2016-03-21; Manufacturer: Texas Instruments; MountType: SMT; PackageReference: RGZ0048B; PartNumber: DRV8323RSRGZR; RoHS: Y; SymbolVersion: 2016-03-15; Library Name: TI_Pre_RTM.IntLib; Publisher: Texas Instruments
